# Altium schematic sheet usb.SchDoc(usb)
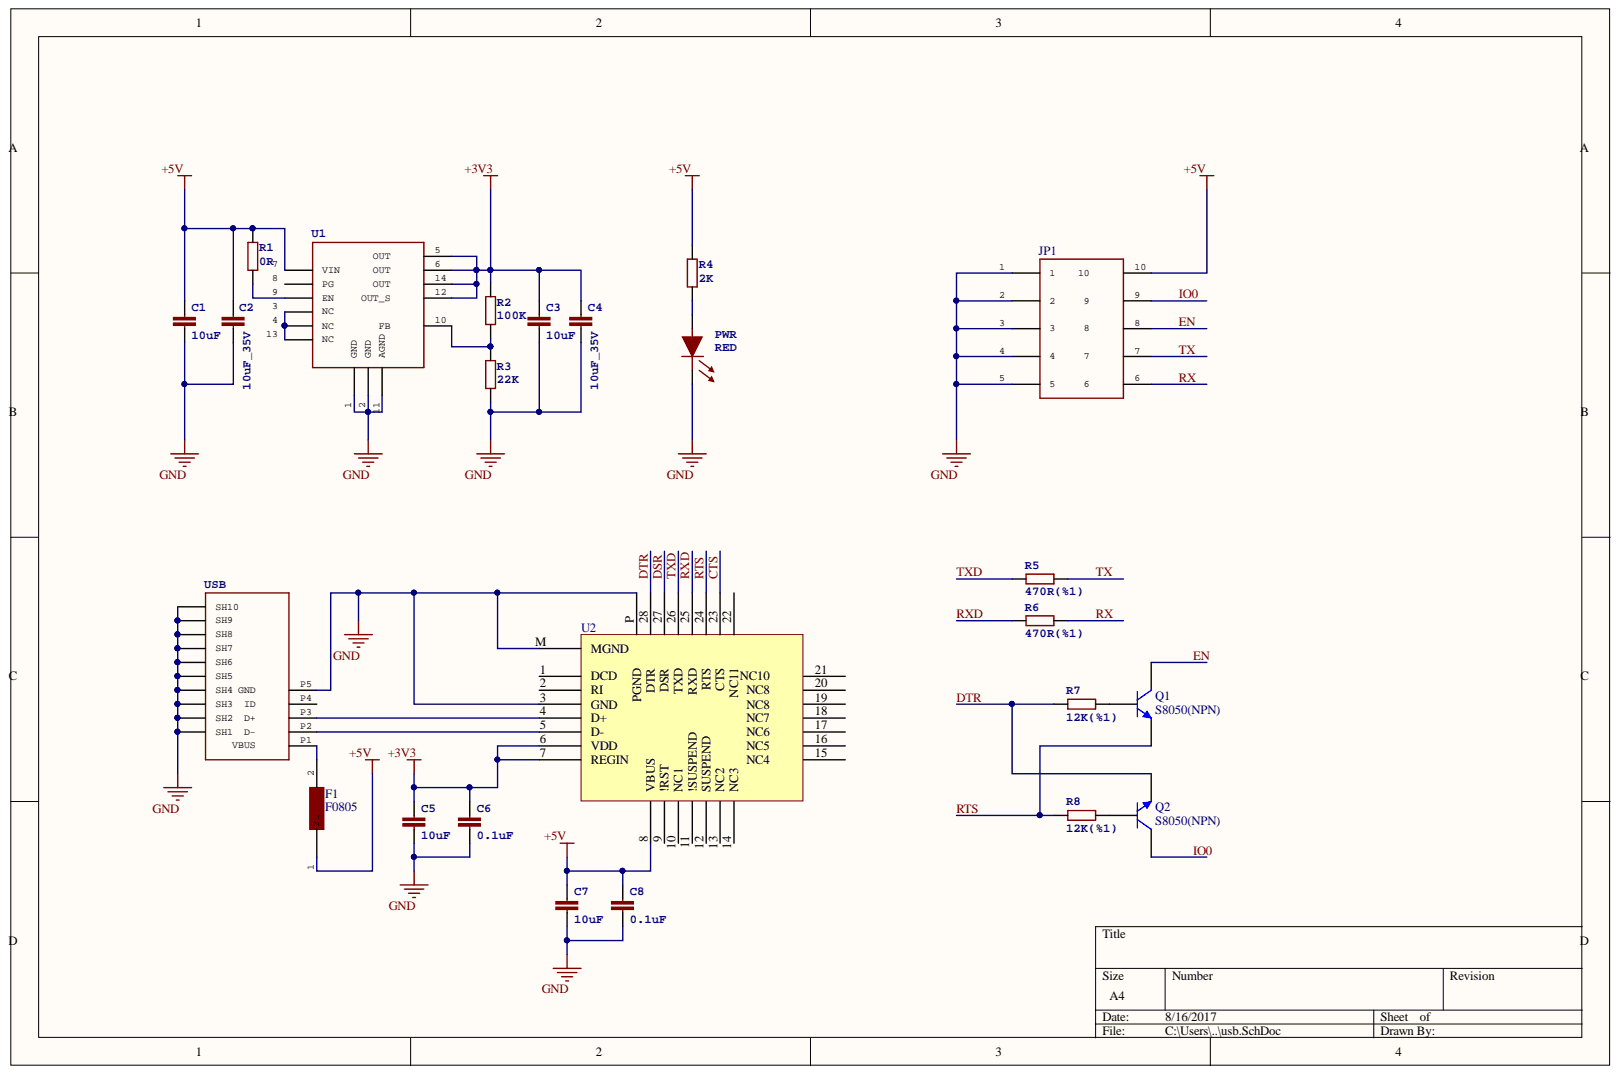
[diagram p0: usb.SchDoc]

## Parts
C1  10uF  [C0603]
C2  10uF_35V  [C1210]
C3  10uF  [C0603]
C4  10uF_35V  [C1210]
C5  10uF  [C0603]
C6  0.1uF  [C0603]
C7  10uF  [C0603]
C8  0.1uF  [C0603]
F1  F0805  [F0805]
JP1  (no value)  [PIN_SMT_2X5_1.27]
PWR  RED  [LED0805]
Q1  S8050(NPN)  [S8050(NPN)]
Q2  S8050(NPN)  [S8050(NPN)]
R1  0R  [R0603]
R2  100K  [R0603]
R3  22K  [R0603]
R4  2K  [R0603]
R5  470R(%1)  [R0603]
R6  470R(%1)  [R0603]
R7  12K(%1)  [R0603]
R8  12K(%1)  [R0603]
U1  (no value)  [MPM3810GQB]
U2  (no value)  [QFN28]
USB  (no value)  [USB_MICRO]

## Nets
+3V3: C3.1 C4.1 C5.1 C6.1 R2.2 U1.5 U1.6 U1.12 U1.14 U2.6(VDD) U2.7(REGIN)
+5V: C1.1 C2.1 C7.1 C8.1 F1.1 JP1.10 R1.2 R4.2 U1.7(VIN) U2.8(VBUS)
GND: C1.2 C2.2 C3.2 C4.2 C5.2 C6.2 C7.2 C8.2 JP1.1 JP1.2 JP1.3 JP1.4 JP1.5 PWR.1 R3.1 U1.1(GND) U1.2(GND) U1.11(AGND) U2.3(GND) U2.M(MGND) U2.P USB.H1(SH7) USB.H2(SH8) USB.H3(SH9) USB.H4(SH10) USB.P5 USB.S1(SH1) USB.S2(SH2) USB.S3(SH3) USB.S4(SH4) USB.S5(SH5) USB.S6(SH6)
CTS: U2.23
DSR: U2.27
DTR: Q2.2 R7.2 U2.28
EN: JP1.8 Q1.3
IO0: JP1.9 Q2.3
RTS: Q1.2 R8.2 U2.24
RX: JP1.6 R6.1
RXD: R6.2 U2.25
TX: JP1.7 R5.2
TXD: R5.1 U2.26
NetF1_2: F1.2 USB.P1
NetPWR_2: PWR.2 R4.1
NetQ1_1: Q1.1 R7.1
NetQ2_1: Q2.1 R8.1
NetR1_1: R1.1 U1.9(EN)
NetR2_1: R2.1 R3.2 U1.10
NetU1_3: U1.3(NC) U1.4(NC) U1.13(NC)
NetU2_4: U2.4(D+) USB.P3
NetU2_5: U2.5(D-) USB.P2

Not connected: U1 8(PG); U2 1(DCD) 2(RI) 9(!RST) 10(NC1) 11(!SUSPEND) 12(SUSPEND) 13(NC2) 14(NC3) 15 16 17 18 19 20 21 22; USB P4

## Library data of the parts
C1 C3 C5-C8 = C-EUC0603: CAPACITOR, European symbol; Component Kind: Standard; Library Name: shenghua.SCHLIB
C2 C4 = C-EUC1210: Component Kind: Standard; Library Name: shenghua.SCHLIB
JP1 = PIN_SMT_2X5-1.27MM: Component Kind: Standard; Library Name: shenghua.SCHLIB
PWR = LED0805: Component Kind: Standard; Library Name: shenghua.SCHLIB
R1-R8 = R-EU_R0603: Component Kind: Standard; Library Name: shenghua.SCHLIB
U1 = MPM3810GQB: Component Kind: Standard; PART_NUMBER: MPM3810GQB; Library Name: shenghua.SCHLIB
U2 = CP2102-QFN_P28: Component Kind: Standard; Library Name: shenghua.SCHLIB
USB = USB_MIRCRO: PART_NUMBER: MCR-B-S-RA-SMT-CS1TR; Component Kind: Standard; Library Name: shenghua.SCHLIB
F1 = F0805: Component Kind: Standard; Library Name: shenghua.SCHLIB
Q1 Q2 = S8050(NPN): Component Kind: Standard; Library Name: shenghua.SCHLIB
